# Altium schematic sheet CAN_.SchDoc
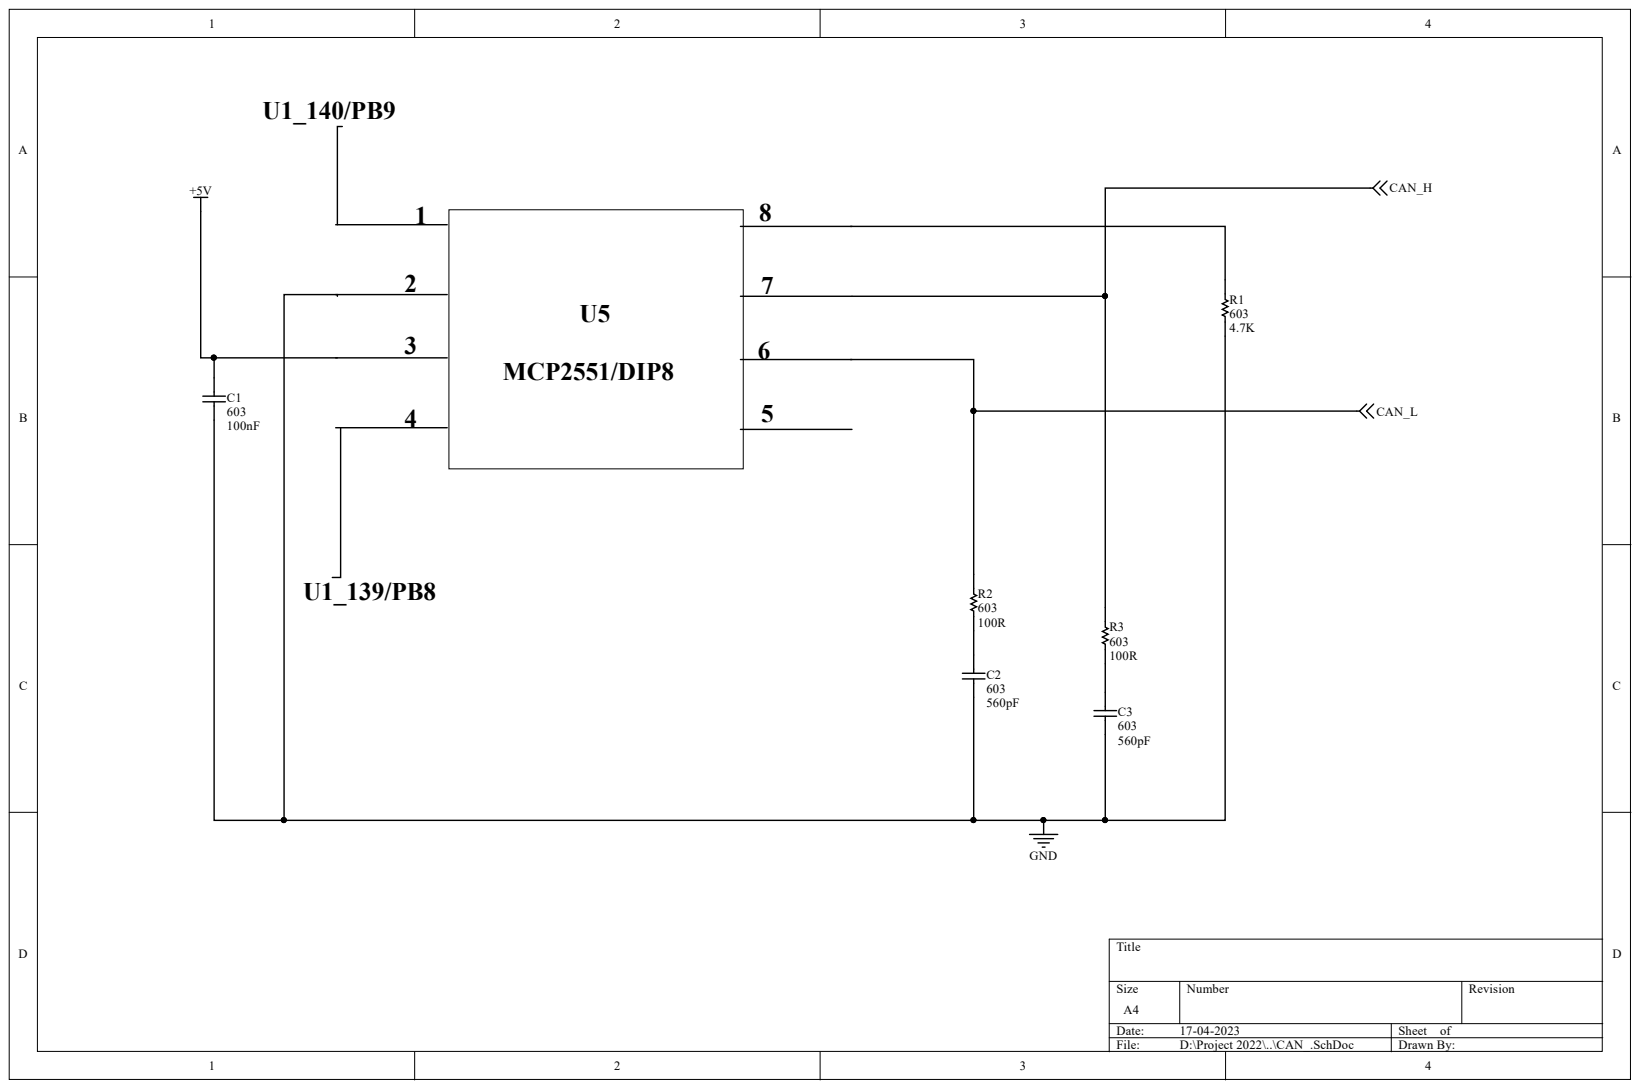
[diagram p0: CAN_.SchDoc]

## Parts
C1  603  value 100nF  [RAD-0.3]
C2  603  value 560pF  [RAD-0.3]
C3  603  value 560pF  [RAD-0.3]
R1  603  value 4.7K  [AXIAL-0.3]
R2  603  value 100R  [AXIAL-0.3]
R3  603  value 100R  [AXIAL-0.3]

## Nets
+5V: C1.2
CAN_H: R3.1
CAN_L: R2.1
GND: C1.1 C2.1 C3.1 R1.2
NetC2_2: C2.2 R2.2
NetC3_2: C3.2 R3.2
N00053:
N00054:

Not connected: R1 1

## Library data of the parts
C1-C3 = Cap: Capacitor; Component Kind: Standard; LatestRevisionDate: 17-Jul-2002; LatestRevisionNote: Re-released for DXP Platform.; Library Name: Miscellaneous Devices.IntLib; PackageDescription: Radial Cap, Thru-Hole; 2 Leads; 0.3 in Pin Spacing; PackageReference: RAD-0.3; Published: 8-Jun-2000; Signal Integrity: Cap; Simulation: CAP; Publisher: Altium Limited
R1-R3 = Res1: Resistor; Component Kind: Standard; LatestRevisionDate: 17-Jul-2002; LatestRevisionNote: Re-released for DXP Platform.; Library Name: Miscellaneous Devices.IntLib; PackageDescription: Axial Device, Thru-Hole; 2 Leads; 0.3 in Pin Spacing; PackageReference: AXIAL-0.3; Published: 8-Jun-2000; Signal Integrity: Res; Simulation: RESISTOR; Publisher: Altium Limited
